# Altium schematic sheet Anybus-Base.SchDoc
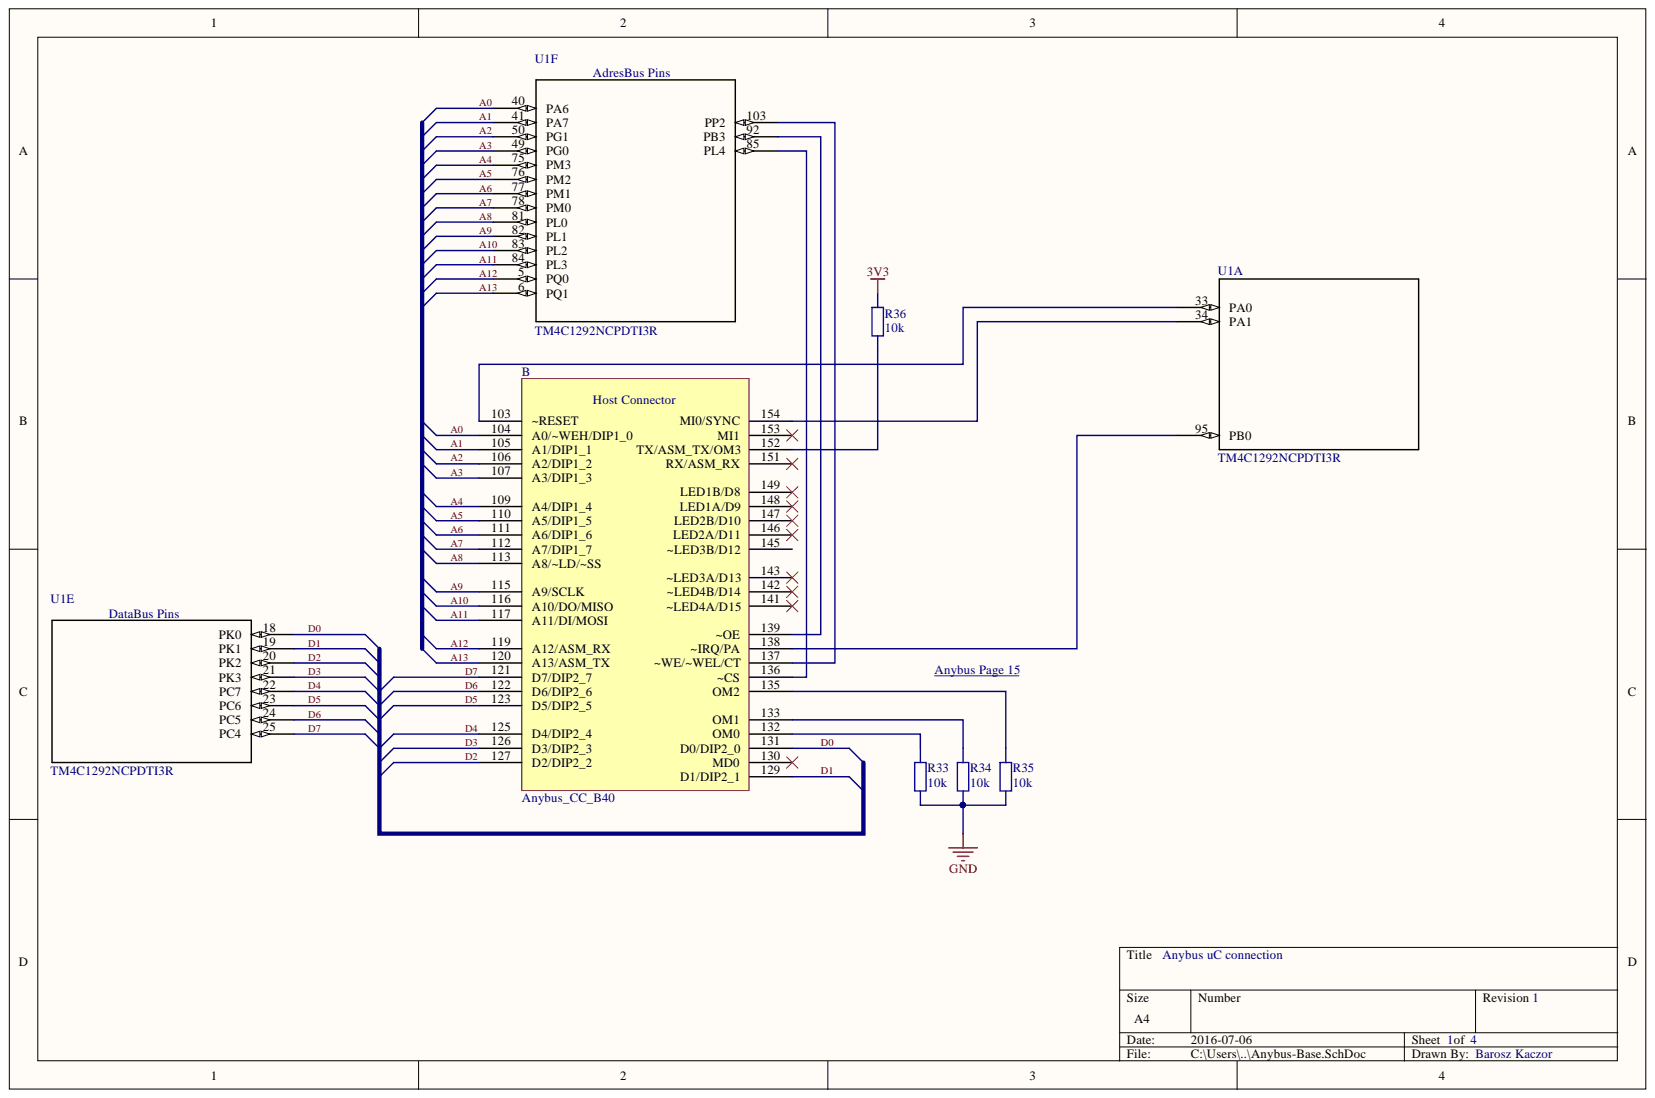
[diagram p0: Anybus-Base.SchDoc]

## Parts
B  Anybus_CC_B40  [Anybus-CC-B40]
R33  10k  [0603_R HighDensity]
R34  10k  [0603_R HighDensity]
R35  10k  [0603_R HighDensity]
R36  10k  [RES0603_M]
U1  TM4C1292NCPDTI3R  [PDT0128A_N]  (parts A,E,F)

## Nets
3V3: R36.2
GND: R33.1 R34.1 R35.1
Net_103: B.103(~RESET) U1.33(PA0)
Net_130: B.130(MD0)
Net_132: B.132(OM0) R33.2
Net_133: B.133(OM1) R34.2
Net_135: B.135(OM2) R35.2
Net_136: B.136(~CS) U1.85(PL4)
Net_137: B.137(~WE/~WEL/CT) U1.103(PP2)
Net_138: B.138(~IRQ/PA) U1.95(PB0)
Net_139: B.139(~OE) U1.92(PB3)
Net_141: B.141(~LED4A/D15)
Net_142: B.142(~LED4B/D14)
Net_143: B.143(~LED3A/D13)
Net_145: B.145(~LED3B/D12)
Net_146: B.146(LED2A/D11)
Net_147: B.147(LED2B/D10)
Net_148: B.148(LED1A/D9)
Net_149: B.149(LED1B/D8)
Net_151: B.151(RX/ASM_RX)
Net_152: B.152(TX/ASM_TX/OM3) R36.1
Net_153: B.153(MI1)
Net_154: B.154(MI0/SYNC) U1.34(PA1)
A0: B.104(A0/~WEH/DIP1_0) U1.40(PA6)
A1: B.105(A1/DIP1_1) U1.41(PA7)
A2: B.106(A2/DIP1_2) U1.50(PG1)
A3: B.107(A3/DIP1_3) U1.49(PG0)
A4: B.109(A4/DIP1_4) U1.75(PM3)
A5: B.110(A5/DIP1_5) U1.76(PM2)
A6: B.111(A6/DIP1_6) U1.77(PM1)
A7: B.112(A7/DIP1_7) U1.78(PM0)
A8: B.113(A8/~LD/~SS) U1.81(PL0)
A9: B.115(A9/SCLK) U1.82(PL1)
A10: B.116(A10/DO/MISO) U1.83(PL2)
A11: B.117(A11/DI/MOSI) U1.84(PL3)
A12: B.119(A12/ASM_RX) U1.5(PQ0)
A13: B.120(A13/ASM_TX) U1.6(PQ1)
D0: B.131(D0/DIP2_0) U1.18(PK0)
D1: B.129(D1/DIP2_1) U1.19(PK1)
D2: B.127(D2/DIP2_2) U1.20(PK2)
D3: B.126(D3/DIP2_3) U1.21(PK3)
D4: B.125(D4/DIP2_4) U1.22(PC7)
D5: B.123(D5/DIP2_5) U1.23(PC6)
D6: B.122(D6/DIP2_6) U1.24(PC5)
D7: B.121(D7/DIP2_7) U1.25(PC4)
N00025:
N00026:

## Library data of the parts
R33-R36 = R: Resistor; Component Kind: Standard; Library Name: Disc-Other.SchLib
U1 = TM4C1292NCPDTI3R: Imported; Manufacturer: Texas Instruments; CompType: IC; PIN_COUNT: 128; Alternate PartNumber: TM4C1292NCPDTI3; Component Kind: Standard; Library Name: TM4C129ENCPDT.SchLib; MountType: SMT; PackageReference: PDT0128A; PartNumber: TM4C1292NCPDTI3R; RefDes: RefDes; RoHS: Y; Type: DEV; Value: Value
B = Anybus_CC_B40: Component Kind: Standard; Library Name: Anybus-CompactCom_B40.SchLib
